# Altium schematic sheet MCU_AND_PW.SchDoc
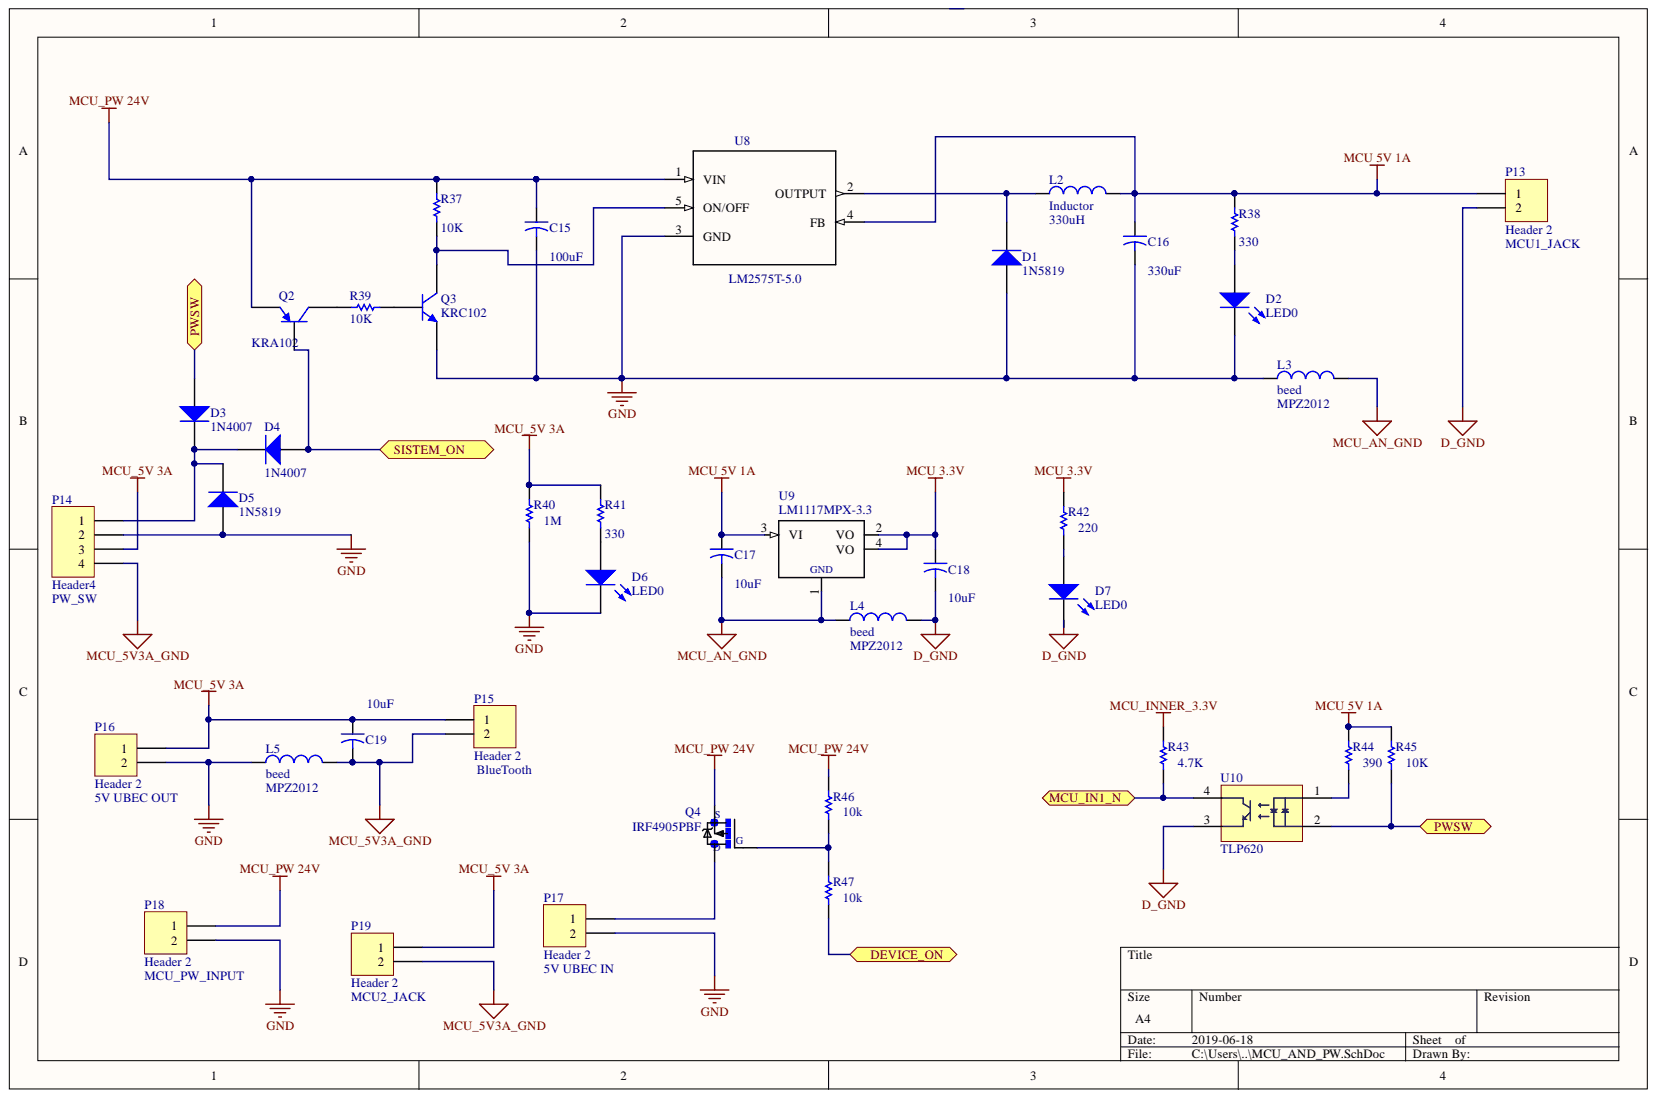
[diagram p0: MCU_AND_PW.SchDoc]

## Parts
C15  value 100uF  [CAPR5-4X5]  (hidden comment: Cap2)
C16  value 330uF  [CAPR5-4X5]  (hidden comment: Cap2)
C17  value 10uF  [CAPR5-4X5]  (hidden comment: Cap2)
C18  value 10uF  [CAPR5-4X5]  (hidden comment: Cap2)
C19  value 10uF  [CAPR5-4X5]  (hidden comment: Cap2)
D1  1N5819  [SMC]
D2  LED0  [LED-0]
D3  1N4007  [SMC]
D4  1N4007  [SMC]
D5  1N5819  [SMC]
D6  LED0  [LED-0]
D7  LED0  [LED-0]
L2  Inductor  value 330uH  [0402-A]
L3  beed  value MPZ2012  [0402-A]
L4  beed  value MPZ2012  [0402-A]
L5  beed  value MPZ2012  [0402-A]
P13  Header 2  [HDR1X2]
P14  Header4  [HDR1X4]
P15  Header 2  [HDR1X2]
P16  Header 2  [HDR1X2]
P17  Header 2  [HDR1X2]
P18  Header 2  [HDR1X2]
P19  Header 2  [HDR1X2]
Q2  KRA102  [SOT-23B_N]
Q3  KRC102  [TO-226-AA]
Q4  IRF4905PBF  [*TO220BH_IRF4905PBF(Primary)]
R37  value 10K  [AXIAL-0.3]  (hidden comment: Res1)
R38  value 330  [AXIAL-0.3]  (hidden comment: Res1)
R39  value 10K  [AXIAL-0.3]  (hidden comment: Res1)
R40  value 1M  [AXIAL-0.3]  (hidden comment: Res1)
R41  value 330  [AXIAL-0.3]  (hidden comment: Res1)
R42  value 220  [AXIAL-0.3]  (hidden comment: Res1)
R43  value 4.7K  [AXIAL-0.3]  (hidden comment: Res1)
R44  value 390  [AXIAL-0.3]  (hidden comment: Res1)
R45  value 10K  [AXIAL-0.3]  (hidden comment: Res1)
R46  value 10k  [AXIAL-0.3]  (hidden comment: Res1)
R47  value 10k  [AXIAL-0.3]  (hidden comment: Res1)
U8  LM2575T-5.0  [*TO170P470X1016X2092-5P]
U9  LM1117MPX-3.3  [*SOT230P700X180-4N]
U10  TLP620

## Ports
DEVICE_ON, MCU_IN1_N, PWSW, SISTEM_ON

## Nets
D_GND: C18.2 D7.2 L4.2 P13.2 U10.3
GND: C15.2 C16.2 D1.1 D2.2 D5.1 D6.2 L3.1 L5.1 P14.2 P16.2 P17.2 P18.2 Q3.3 R40.1 U8.3(GND)
MCU 3.3V: C18.1 R42.2 U9.2(VO) U9.4(VO)
MCU 5V 1A: C16.1 C17.1 L2.2 P13.1 R38.2 R44.2 R45.2 U8.4(FB) U9.3(VI)
MCU_5V3A_GND: C19.2 L5.2 P14.4 P15.2 P19.2
MCU_5V 3A: C19.1 P14.3 P15.1 P16.1 P19.1 R40.2 R41.2
MCU_AN_GND: C17.2 L3.2 L4.1 U9.1
MCU_INNER_3.3V: R43.2
MCU_PW 24V: C15.1 P18.1 Q2.3 Q4.S R37.2 R46.2 U8.1(VIN)
DEVICE_ON: R47.1 ; port DEVICE_ON
MCU_IN1_N: R43.1 U10.4 ; port MCU_IN1_N
PWSW: D3.1 R45.1 U10.2 ; port PWSW
SISTEM_ON: D4.1 Q2.2 ; port SISTEM_ON
NetD1_2: D1.2 L2.1 U8.2(OUTPUT)
NetD2_1: D2.1 R38.1
NetD3_2: D3.2 D4.2 D5.2 P14.1
NetD6_1: D6.1 R41.1
NetD7_1: D7.1 R42.1
NetP17_1: P17.1 Q4.D
NetQ2_1: Q2.1 R39.2
NetQ3_1: Q3.1 R37.1 U8.5(ON/OFF)
NetQ3_2: Q3.2 R39.1
NetQ4_G: Q4.G R46.1 R47.2
NetR44_1: R44.1 U10.1
N00010:

## Library data of the parts
C15-C19 = Cap2: Capacitor; Component Kind: Standard; Library Name: Miscellaneous Devices.IntLib; PackageDescription: Capacitor; 2 Leads; PackageReference: CAPR5-4X5; Published: 23-Sep-2002; Signal Integrity: Cap; Simulation: CAP; Publisher: Altium Limited
D1 D3-D5 = Diode: Default Diode; Code_JEDEC: DO-214-AB; Component Kind: Standard; LatestRevisionDate: 17-Jul-2002; LatestRevisionNote: Re-released for DXP Platform.; Library Name: Miscellaneous Devices.IntLib; PackageDescription: DO-214-AB/SMC; 2 C-Bend Leads; Body 7.9 x 5.9 mm, inc. leads (LxW); PackageReference: SMC; PackageVersion: Sep-1996; Published: 8-Jun-2000; Signal Integrity: Diode; Simulation: DIODE; Publisher: Altium Limited
D2 D6 D7 = LED0: Typical INFRARED GaAs LED; Component Kind: Standard; LatestRevisionDate: 08-Jul-2005; LatestRevisionNote: Mech Layer 1 removed.; Library Name: Miscellaneous Devices.IntLib; PackageDescription: LED; 2 Leads; PackageReference: LED-0; Published: 8-Jun-2000; Simulation: LED0; Publisher: Altium Limited
L2-L5 = Inductor: Code_IEC: 1005; Code_JEITA: 0402; Component Kind: Standard; Description: Inductor; LatestRevisionDate: 29-May-2009; LatestRevisionNote: IPC-7351 Footprint Added.; Library Name: Miscellaneous Devices.IntLib; PackageDescription: Chip Inductor; PackageReference: 0402-A; Published: 8-Jun-2000; Signal Integrity: Inductor; Simulation: INDUCTOR; Publisher: Altium Limited
P13 P15-P19 = Header 2: Header, 2-Pin; Component Kind: Standard; LatestRevisionDate: 17-Jul-2002; LatestRevisionNote: Re-released for DXP Platform.; Library Name: Miscellaneous Connectors.IntLib; Signal Integrity: Connector; Publisher: Altium Limited
P14 = Header 4: Header, 4-Pin; Component Kind: Standard; LatestRevisionDate: 17-Jul-2002; LatestRevisionNote: Re-released for DXP Platform.; Library Name: Miscellaneous Connectors.IntLib; Signal Integrity: Connector; Publisher: Altium Limited
Q2 = PNP: PNP Bipolar Transistor; Component Kind: Standard; LatestRevisionDate: 29-May-2009; LatestRevisionNote: IPC-7351 Footprint Added.; Library Name: Miscellaneous Devices.IntLib; PackageDescription: 3-Pin SOT-23 Package 0.95 mm Pitch, 2.4 mm Lead Span; PackageReference: SOT-23B; PackageVersion: Aug-1999; Published: 8-Jun-2000; Signal Integrity: PNP; Simulation: PNP; Publisher: Altium Limited
Q3 = NPN: NPN Bipolar Transistor; Code_JEDEC: TO-226-AA; Component Kind: Standard; LatestRevisionDate: 17-Jul-2002; LatestRevisionNote: Re-released for DXP Platform.; Library Name: Miscellaneous Devices.IntLib; PackageDescription: TO, Flat Index; 3 In-Line, Axial Leads; Body Dia. 4.8mm; Leads 0.55 x 0.51 mm (max); PackageReference: TO-226-AA; Published: 8-Jun-2000; Signal Integrity: NPN; Simulation: NPN; Publisher: Altium Limited
Q4 = IRF4905PBF: MOSFET, Power; P-Ch; VDSS -55V; RDS_ON_ 0.02Ohm; ID -74A; TO-220AB; PD 200W; VGS +/-20V; MF: Infineon; Availability: Unavailable; Component Kind: Standard; Library Name: IRF4905PBF.SchLib; MP: IRF4905PBF; Package: TO-220 Infineon; Price: None; SnapEDA_Link: https://www.snapeda.com/parts/IRF4905PBF/Infineon%20Technologies/view-part/?ref=snap
R37-R47 = Res1: Resistor; Component Kind: Standard; LatestRevisionDate: 17-Jul-2002; LatestRevisionNote: Re-released for DXP Platform.; Library Name: Miscellaneous Devices.IntLib; PackageDescription: Axial Device, Thru-Hole; 2 Leads; 0.3 in Pin Spacing; PackageReference: AXIAL-0.3; Published: 8-Jun-2000; Signal Integrity: Res; Simulation: RESISTOR; Publisher: Altium Limited
U8 = LM2575T-5.0: SIMPLE SWITCHER 1A Step-Down Voltage Regulator 5-TO-220 -40 to 125; MF: Texas Instruments; Availability: Unavailable; Component Kind: Standard; Library Name: LM2575T-5.0.IntLib; MP: LM2575T-5.0; Package: TO-220 Texas Instruments; Price: None; SnapEDA_Link: https://www.snapeda.com/parts/LM2575T-5.0/Texas%20Instruments/view-part/?ref=snap
U9 = LM1117MPX-3.3: 4 pin 800mA Low-Dropout Linear Regulator 2000 per reel; MF: Texas Instruments; Availability: Unavailable; Component Kind: Standard; Library Name: LM1117MPX-3.3.IntLib; MP: LM1117MPX-3.3; Package: SOT-223 Texas Instruments; Price: None; SnapEDA_Link: https://www.snapeda.com/parts/LM1117MPX-3.3/Texas%20Instruments/view-part/?ref=snap
U10 = TLP620: Component Kind: Standard; Library Name: cannon_lib.SchLib
